# Altium schematic sheet Inresol_grid_inverter_DSPIC.SchDoc(Inresol_grid_inverter_DSPIC) (continued)

## Library data of the parts
C500 C502-C505 C510-C513 C515 C518 C521-C523 C525 C528 C541 C543 C550 C551 = C-100nF-50V-Cer-0603: Cap 0603 SM X7R 50V 10%; MFR: AVX; MFR PN: 06035C104K4T2A; Future PN (CT): 06035C104K4TN-CT; Future PN (TR): 06035C104K4TN; Rating: 50V 10%; Component Kind: Standard; ComponentLink1Description: Datasheet; ComponentLink1URL: http://www.avx.com/docs/Catalogs/cx7r.pdf; Library Name: EMEA CAPACITORS_FEB2016.SchLib; Verified: AD030615
C501 = C-10nF-25V-Cer-0603: Cap 0603 SM X7R 25V 10%; MFR: AVX; MFR PN: 06033C103KAT2A; Future PN (CT): 06033C103KATN-CT; Future PN (TR): 06033C103KATN; Rating: 25V 10%; Component Kind: Standard; ComponentLink1Description: Datasheet; ComponentLink1URL: http://www.avx.com/docs/Catalogs/ccog.pdf; Library Name: Future Capacitors.SCHLIB
C506 C507 C514 C516 C517 C524 C526 C537-C540 C542 C544-C549 C552-C567 = C-1nF-50V-Cer-0603: Cap 0603 SM X7R 50V 10%; MFR: VISHAY; MFR PN: VJ0603Y102KXACW1BC; Future PN (CT): 06035C102KATN-CT; Future PN (TR): 06035C102KATN; Rating: 50V 10%; Component Kind: Standard; ComponentLink1Description: Datasheet; ComponentLink1URL: http://www.vishay.com/docs/28548/vjw1bcbascomseries.pdf; Library Name: Future Capacitors.SCHLIB; Verified: AD030615
C508 = C-10uF-10V-Tant-1206: Cap 1206 SM Tant 10V 10%; MFR: AVX; MFR PN: TPSA106K010R0900; Future PN (CT): 106K10AP0900; Rating: 10V 10%; Component Kind: Standard; ComponentLink1Description: Datasheet; ComponentLink1URL: http://www.futureelectronics.com/en/technologies/passives/capacitors/tantalum-capacitors/Pages/5440110-TPSA106K010R0900.aspx?IM=0; Future PN (TR): 106K10AP0900; Library Name: Inresol_grid_inverter.SchLib
C509 C532 C533 = C-10uF-50V-Cer-1206: Cap 1206 SM X5R 50V 20%; MFR: TDK; MFR PN: C3216X5R1H106M160AB; Future PN (CT): TBD; Future PN (TR): 12065D106MATN; Rating: 50V 20%; Component Kind: Standard; ComponentLink1Description: Datasheet; ComponentLink1URL: http://product.tdk.com/capacitor/mlcc/en/documents/mlcc_commercial_general_en.pdf; Library Name: EMEA CAPACITORS_FEB2016.SchLib; Verified: AD030615
C519 C520 = C-10uF-10V-Cer-1206: Cap 1206 SM X7R 10V 10%; MFR: AVX; MFR PN: 1206ZG106ZAT2A; Future PN (CT): 1206ZG106ZATN-CT; Future PN (TR): 1206ZG106ZATN; Rating: 10V 10%; Component Kind: Standard; ComponentLink1Description: Datasheet; ComponentLink1URL: http://www.avx.com/docs/Catalogs/cy5v.pdf; Library Name: EMEA CAPACITORS_FEB2016.SchLib
C527 = C-22uF-16V-Tant-1210: Cap 1210 SM Tant 16V 10%; MFR: AVX; MFR PN: TPSB226K016R0600; Future PN (CT): 226K16BP0600-CT; Future PN (TR): 226K16BP0600; Rating: 16V 10%; Component Kind: Standard; ComponentLink1Description: Datasheet; ComponentLink1URL: http://www.avx.com/docs/masterpubs/tantniob.pdf; Library Name: Future Capacitors.SCHLIB; Verified: AD030615
C529 C534 = C-1uF-25V-Cer-0603: Cap 0603 SM X5R 25V 10%; MFR: YAGEO; MFR PN: CC0603KRX5R8BB105; Future PN (CT): 06033D105KATN-CT; Future PN (TR): 06033D105KATN; Rating: 25V 10%; Component Kind: Standard; ComponentLink1Description: Datasheet; ComponentLink1URL: http://www.yageo.com/documents/recent/UPY-GPHC_X5R_4V-to-50V_12.pdf; Library Name: Future Capacitors.SCHLIB; Verified: AD030615
C530 = C-47nF-50V-Cer-0603: Cap 0603 SM X7R 50V 10%; MFR: YAGEO; MFR PN: CC0603KRX7R9BB473; Future PN (CT): 06035C473KATN-CT; Future PN (TR): 06035C473KATN; Rating: 50V 10%; Component Kind: Standard; ComponentLink1Description: Datasheet; ComponentLink1URL: http://www.yageo.com/documents/recent/UPY-GPHC_X7R_6.3V-to-50V-11.pdf; Library Name: Future Capacitors.SCHLIB; Verified: AD030615
C531 = C-470PF-500VAC-Cer-8X5-LS10-Y1-EMEA-06-09-2017: Cap  8X5 LS10 RADIAL Y5U 500VAC 20% Y1; MFR: VISHAY; MFR PN: VY1471M31Y5UQ63V0; Apr'd: RAISED EMEA 12TH FEB 2013; Future PN (CT): TBD; Value: 470PF; Component Kind: Standard; ComponentLink1Description: Datasheet; ComponentLink1URL: http://www.vishay.com/docs/28537/emirfiy1.pdf; Future PN (TR): TBD; Library Name: Inresol_grid_inverter.SchLib
C535 = C-330uF-25V-Elec-8x10-EMEA-06-09-2017: Cap 8x10 SM Alum 25V 20%; MFR: Panasonic; MFR PN: EEE-FK1E331P; Future PN (CT): TBD; Rating: 25V 20%; Component Kind: Standard; ComponentLink1Description: Datasheet; ComponentLink1URL: https://industrial.panasonic.com/ww/products/capacitors/aluminum-capacitors/aluminum-cap-smd/fk-v/EEEFK1E331P; Future PN (TR): TBD; Library Name: Inresol_grid_inverter.SchLib
C536 = C-470PF-500VAC-Cer-8X5-LS10-Y1-EMEA-06-09-2017: Cap  8X5 LS10 RADIAL Y5U 500VAC 20% Y1; MFR: VISHAY; MFR PN: VY1471M31Y5UQ63V0; Apr'd: RAISED EMEA 12TH FEB 2013; Future PN (CT): TBD; Component Kind: Standard; ComponentLink1Description: Datasheet; ComponentLink1URL: http://www.vishay.com/docs/28537/emirfiy1.pdf; Future PN (TR): TBD; Library Name: Inresol_grid_inverter.SchLib
D500-D503 = D-SOD323-BAT760-7: Diode Schottky 30V 1A SMD; MFR: DIO; Future PN (CT): TBD; Component Kind: Standard; ComponentLink1Description: Datasheet; ComponentLink1URL: https://www.diodes.com/assets/Datasheets/ds30498.pdf; Future PN (TR): TBD; Library Name: Inresol_grid_inverter.SchLib
D504 = D-TSOP5-VREF-TLV431A-EMEA: Voltage reference adjustable 1.24V TLV431A; MFR: ON SEMI; Apr'd: raised EMEA 4th Jan 2013; Future PN (CT): TBA; Future PN (TR): TLV431ACDBVR; Component Kind: Standard; ComponentLink1Description: Datasheet; ComponentLink1URL: http://www.onsemi.com/pub_link/Collateral/TLV431A-D.PDF; Library Name: EMEA SEMICONDUCTORS_FEB2016.SchLib
J500 J501 J506 = J-HDR100-2x3-TH: Header, Male 6-Pin, LS100mil, Dual row; MFR: Tyco; Future PN (CT): NSH-06DB-S1-TG30-PB; Future PN (TR): TBD; Rating: 3 Amp; Value: 6 Pin; Component Kind: Standard; ComponentLink1Description: Datasheet; ComponentLink1URL: http://www.te.com/commerce/DocumentDelivery/DDEController?Action=showdoc&DocId=Customer+Drawing%7F103783%7FG2%7Fpdf%7FEnglish%7FENG_CD_103783_G2.pdf%7F103783-3; Library Name: Future Connectors.SCHLIB; Revision: 17-Jul-2002: Re-released for DXP Platform.; Signal Integrity: Connector
J502 = J-HDR100-2x7-TH: Header, Male 14-Pin,  LS100mil, Dual row; MFR: ADAM TECH; Future PN (CT): NSH-14DB-S2-T; Future PN (TR): TBD; Value: 2X7 Pin; Component Kind: Standard; ComponentLink1Description: Datasheet; ComponentLink1URL: http://www.adam-tech.com/rick/FinalSingle/CatPg250-254.pdf; Library Name: Future Connectors.SCHLIB; Signal Integrity: Connector
J503 J504 = J-RJ45-106066-2-EMEA-30-11-2016: Connector RJ45 RA TH Shielded 8 position; MFR: TE; Future PN (CT): TBD; Component Kind: Standard; ComponentLink1Description: Datasheet; ComponentLink1URL: http://www.te.com/usa-en/product-106066-2.html#pdp-docs-features; Future PN (TR): TBD; MFR PN: 106066-2; Signal Integrity: Connector; Library Name: Inresol_grid_inverter.SchLib
J505 = J-ADC-002A-1-2mm-EMEA-24-08-2017: Coax Connector 2mm; MFR: Adam Tech; Future PN (CT): TBD; Rating: 12V 1Amp; Rating: 3A; Component Kind: Standard; ComponentLink1Description: Datasheet; ComponentLink1URL: http://www.adam-tech.com/rick/FinalSingle/CatPg200-203.pdf; Future PN (TR): TBD; Value: 2mm; Library Name: Inresol_grid_inverter.SchLib
L500 = L-BLM21P-0805-330Ohm-1.5A-EMEA-06-09-2017: Ferrite Bead, 330ohm@100MHz, 0.09Ohm, 1.5A, 0805; MFR: MURATA; MFR PN: BLM21PG331SN1D; Future PN (CT): BLM21P331SGPT-CT; Future PN (TR): BLM21P331SGPT; Component Kind: Standard; ComponentLink1Description: Datasheet; ComponentLink1URL: http://www.murata.com/products/catalog/pdf/c31e.pdf; Library Name: Inresol_grid_inverter.SchLib
LED500-LED502 = LED-0603-Ever: Standard LED (Green); MFR: Everlight; MFR PN: QTLP600C4TR; Future PN (TR): QTLP600C-4.TR; Rating: 30mA; Value: GREEN LED; Component Kind: Standard; ComponentLink1Description: Datasheet; ComponentLink1URL: http://www.everlight.com/everlightinternational/lamp_datasheet/ds300084.pdf; Future PN (CT): N/A; Library Name: Future LED.SchLib
P500 = J-HDR100-6PIN-TH_TE: Header, Male 6-Pin, LS100mil; MFR: TE; Future PN (CT): TBD; Rating: 3Amp; Value: 6 Pin; Component Kind: Standard; ComponentLink1Description: Datasheet; ComponentLink1URL: http://www.te.com/catalog/pn/en/5-146278-6?RQPN=5-146278-6; Future PN (TR): TBD; Library Name: Future Connectors.SCHLIB; Signal Integrity: Connector
Q500 = Q-SOT23-BC847BLT1G: Transistor NPN SW, 45V 100mA SOT23; MFR: ON SEMI; Apr'd: YES; Future PN (CT): BC847BLT1-CT; Component Kind: Standard; ComponentLink1Description: Datasheet; ComponentLink1URL: http://www.onsemi.com/pub_link/Collateral/BC846ALT1-D.PDF; Future PN (TR): BC847BLT1; Library Name: Future Semiconductors.SCHLIB; Rating: 45V 100mA; Signal Integrity: MMBT2222A; Simulation: QMMBT2222A
Q501-Q504 = Q-PMV45EN2R: Mosfet N-Chan SOT23 30Vds 5.4A 42mOhmRds-EMEA-10-10-2016; MFR: NXP; Future PN (CT): TBD; Component Kind: Standard; ComponentLink1Description: Datasheet; ComponentLink1URL: http://images.ihscontent.net/vipimages/VipMasterIC/IC/PHGL/PHGLS23686/PHGLS23686-1.pdf; Future PN (TR): TBD; Rating: 30Vds 5.4A; Library Name: Inresol_grid_inverter.SchLib
R500 R503 R506 R510-R512 R529 R533-R538 = R-10k-100mW-0603: Res 0603 SM 100mW 1% 100ppm; MFR: YAGEO; MFR PN: RC0603FR-0710KL; Future PN (CT): CR0603-1002FTR-CT; Future PN (TR): CR0603-1002FTR; Component Kind: Standard; ComponentLink1Description: Datasheet; ComponentLink1URL: http://www.yageo.com/documents/recent/PYu-RC0603_51_RoHS_L_4.pdf; Library Name: Future Resistors.SCHLIB; Rating: 100mW 1%
R501 R504 = R-20k-100mW-0603: Res 0603 SM 100mW 1% 100ppm; MFR: YAGEO; MFR PN: RC0603FR-0720KL; Future PN (CT): CR0603-2002FTR-CT; Future PN (TR): CR0603-2002FTR; Component Kind: Standard; ComponentLink1Description: Datasheet; ComponentLink1URL: http://www.yageo.com/documents/recent/PYu-RC0603_51_RoHS_L_4.pdf; Library Name: Future Resistors.SCHLIB; Rating: 100mW 1%
R502 R505 R519 R526 R541-R546 R552-R557 R563-R584 = R-1k-100mW-0603: Res 0603 SM 100mW 1% 100ppm; MFR: YAGEO; MFR PN: RC0603FR-071KL; Future PN (CT): CR0603-1001FTR-CT; Future PN (TR): CR0603-1001FTR; Component Kind: Standard; ComponentLink1Description: Datasheet; ComponentLink1URL: http://www.yageo.com/documents/recent/PYu-RC0603_51_RoHS_L_4.pdf; Rating: 100mW 1%
  R502 R505: Library Name: Future Resistors.SCHLIB
  R519 R526 R541-R546 R552-R557 R563-R584: Library Name: EMEA RESISTORS_FEB2016.SchLib
R507-R509 = R-5.6k-100mW-0603: Res 0603 SM 100mW 1% 100ppm; MFR: YAGEO; MFR PN: RC0603FR-075K6L; Future PN (CT): CR0603-5601FTR-CT; Future PN (TR): CR0603-5601FTR; Component Kind: Standard; ComponentLink1Description: Datasheet; ComponentLink1URL: http://www.yageo.com/documents/recent/PYu-RC0603_51_RoHS_L_4.pdf; Library Name: Future Resistors.SCHLIB; Rating: 100mW 1%
R513-R515 R523 R524 R539 R540 = R-51k-100mW-0603: Res 0603 SM 100mW 1% 100ppm; MFR: YAGEO; MFR PN: RC0603FR-0751KL; Future PN (CT): CR0603-5102FTR-CT; Future PN (TR): CR0603-5102FTR; Component Kind: Standard; ComponentLink1Description: Datasheet; ComponentLink1URL: http://www.yageo.com/documents/recent/PYu-RC0603_51_RoHS_L_4.pdf; Library Name: Future Resistors.SCHLIB; Rating: 100mW 1%
R516-R518 = R-220R-100mW-0603: Res 0603 SM 100mW 1% 100ppm; MFR: YAGEO; MFR PN: RC0603FR-07220RL; Future PN (CT): CR0603-2200FTR-CT; Future PN (TR): CR0603-2200FTR; Component Kind: Standard; ComponentLink1Description: Datasheet; ComponentLink1URL: http://www.yageo.com/documents/recent/PYu-RC0603_51_RoHS_L_4.pdf; Library Name: Future Resistors.SCHLIB; Rating: 100mW 1%
R520 R525 = R-6.8k-100mW-0603: Res 0603 SM 100mW 1% 100ppm; MFR: YAGEO; MFR PN: RC0603FR-076K8L; Future PN (CT): CR0603-6801FTR-CT; Future PN (TR): CR0603-6801FTR; Component Kind: Standard; ComponentLink1Description: Datasheet; ComponentLink1URL: http://www.yageo.com/pdf/Pu-RC0603_51_PbFree_L_2.pdf; Library Name: Future Resistors.SCHLIB; Rating: 100mW 1%
R521 = R-680R-100mW-0603: Res 0603 SM 100mW 1% 100ppm; MFR: YAGEO; MFR PN: RC0603FR-07680RL; Future PN (CT): CR0603-6800FTR-CT; Future PN (TR): CR0603-6800FTR; Component Kind: Standard; ComponentLink1Description: Datasheet; ComponentLink1URL: http://www.yageo.com/documents/recent/PYu-RC0603_51_RoHS_L_4.pdf; Library Name: Future Resistors.SCHLIB; Rating: 100mW 1%
R522 = R-120R-1W-2512-EMEA-01-12-2016: Res 2512 SM 1W 1%; MFR: VISHAY; MFR PN: CRCW2512120RJNEG; Future PN (CT): TBD; Component Kind: Standard; ComponentLink1Description: Datasheet; ComponentLink1URL: http://www.vishay.com/docs/20035/dcrcwe3.pdf; Future PN (TR): TBD; Library Name: Inresol_grid_inverter.SchLib
R527 R528 = R-330R-100mW-0603: Res 0603 SM 100mW 1% 100ppm; MFR: YAGEO; MFR PN: RC0603FR-07330RL; Future PN (CT): CR0603-3300FTR-CT; Future PN (TR): CR0603-3300FTR; Component Kind: Standard; ComponentLink1Description: Datasheet; ComponentLink1URL: http://www.yageo.com/documents/recent/PYu-RC0603_51_RoHS_L_4.pdf; Library Name: Future Resistors.SCHLIB; Rating: 100mW 1%
R530 R547-R550 = R-100k-100mW-0603: Res 0603 SM 100mW 1% 100ppm; MFR: ROHM; MFR PN: MCR03EZPFX1003; Future PN (CT): CR0603-1003FTR-CT; Future PN (TR): CR0603-1003FTR; Component Kind: Standard; ComponentLink1Description: Datasheet; ComponentLink1URL: http://www.rohm.com/products/databook/r/pdf/mcr03.pdf; Library Name: Future Resistors.SCHLIB; Rating: 100mW 1%
R531 = R-150R-125mW-0805: Res 0805 SM 125mW 1% 100ppm; MFR: VISHAY; MFR PN: CRCW0805150RFKEA; Future PN (CT): CR0805-1500FTR-CT; Future PN (TR): CR0805-1500FTR; Component Kind: Standard; ComponentLink1Description: Datasheet; ComponentLink1URL: http://www.vishay.com/docs/20035/dcrcwe3.pdf; Library Name: EMEA RESISTORS_FEB2016.SchLib; Signal Integrity: Res; Simulation: RESISTOR
R532 = R-3.3k-100mW-0603: Res 0603 SM 100mW 1% 100ppm; MFR: YAGEO; MFR PN: RC0603FR-073K3L; Future PN (CT): CR0603-3301FTR-CT; Future PN (TR): CR0603-3301FTR; Component Kind: Standard; ComponentLink1Description: Datasheet; ComponentLink1URL: http://www.yageo.com/documents/recent/PYu-RC0603_51_RoHS_L_4.pdf; Library Name: Future Resistors.SCHLIB; Rating: 100mW 1%
R551 = R-100R-100mW-0603: Res 0603 SM 100mW 1% 100ppm; MFR: YAGEO; MFR PN: RC0603FR-07100RL; Future PN (CT): CR0603-1000FTR-CT; Future PN (TR): CR0603-1000FTR; Component Kind: Standard; ComponentLink1Description: Datasheet; ComponentLink1URL: http://www.yageo.com/documents/recent/PYu-RC0603_51_RoHS_L_4.pdf; Library Name: Future Resistors.SCHLIB; Rating: 100mW 1%
R558-R562 = R-0R-100mW-0603: Res 0603 SM 100mW; MFR: YAGEO; MFR PN: RC0603JR-070RL; Future PN (CT): CR0603-0000JTR-R-CT; Future PN (TR): CR0603-0000JTR-R; Component Kind: Standard; ComponentLink1Description: Datasheet; ComponentLink1URL: http://www.rohm.com/products/databook/r/pdf/mcr03.pdf; Library Name: Future Resistors.SCHLIB; Rating: 100mW
R585 = R-0R-250mW-1206: Res 1206 SM 250mW; MFR: YAGEO; MFR PN: RC1206JR-070RL; Future PN (CT): CR1206-0000JTR-CT; Future PN (TR): CR1206-0000JTR; Component Kind: Standard; ComponentLink1Description: Datasheet; ComponentLink1URL: http://www.yageo.com/documents/recent/PYu-RC1206_51_RoHS_L_4.pdf; Library Name: Future Resistors.SCHLIB; Rating: 250mW
SW500 = SW-SPST-MOM-2-1437565-7-emea-18-5-15: Low Profile MOM Tac SPST SMD GW-leads; MFR: TE; Future PN (TR): FSM2JSMA; Rating: 32VDC 50mA; Component Kind: Standard; ComponentLink1Description: Datasheet; ComponentLink1URL: http://www.te.com/commerce/DocumentDelivery/DDEController?Action=selcritrslt&searchby=part&searchfor=2-1437565-7&doctypes=All&docformats=All&doclangs=All&TCPN=2-1437565-7; Library Name: EMEA MISC_FEB2016.SchLib; MFR PN: 2-1437565-7
TP500-TP504 TP507-TP511 TP513 TP514 TP516 TP518 TP520 TP522 TP523 = TP-TEST POINT-LOOP WT-EMEA-15-10-15: Test Point; MFR: NA; Future PN (CT): NONE; Future PN (TR): TBD; ComponentLink1Description: Datasheet; Library Name: EMEA MISC_FEB2016.SchLib; MFR PN: NA; Signal Integrity: Connector
U500 = U-TQFP64-dsPIC33EP128GS706: IC, dsPIC, 16-Bit Digital Signal Controller with High-Speed PWM, ADC and Comparators; MFR: Microchip; Apr'd: NO; Future PN (CT): TBD; Component Kind: Standard; ComponentLink1Description: Datasheet; ComponentLink1URL: http://www.microchip.com/wwwproducts/en/dsPIC33EP128GS706; Future PN (TR): TBD; Library Name: Inresol_grid_inverter.SchLib
U501 = U-APX823-26W5G-7: Interface MCU Supervisor Monitor Reset Open-Drain SOT23-5 SM 2.63V; MFR: DIODES; Apr'd: YES; Future PN (CT): TBD; Rating: 2.63V Monitor; Component Kind: Standard; ComponentLink1Description: Datasheet; ComponentLink1URL: http://diodes.com/datasheets/APX823_824_825A.pdf; Future PN (TR): TBD; Library Name: Future Semiconductors.SCHLIB; Verified: AD031615
U502 = U-NCP1117ST50T3G-EMEA-24-08-2017: PMIC LDO Regulator SOT223 SM UpTo 18Vin 1A 5Vout; MFR: ON SEMI; Future PN (CT): TBD; Rating: 5V reg; VModel: xxMMDDYY; Component Kind: Standard; ComponentLink1Description: Datasheet; ComponentLink1URL: http://images.ihscontent.net/vipimages/VipMasterIC/IC/ONSM/ONSM-S-A0000202102/ONSM-S-A0000202102-1.pdf; Future PN (TR): TBD; Library Name: Inresol_grid_inverter.SchLib; Verified: AD032816
U503 = U-SO8-VO2601-X007T-EMEA-15-03-2017: IC, Single Chan 10MBPS Logic Gate Opto Coupler SO8; MFR: Vishay; Future PN (CT): TBD; Rating: 3.3V 10MPS; Component Kind: Standard; ComponentLink1Description: Datasheet; ComponentLink1URL: http://www.vishay.com/docs/84732/6n137.pdf; Future PN (TR): TBD; MFR PN: VO2601-X007T; Library Name: Inresol_grid_inverter.SchLib
U504 = U-SO14-SP3083-EMEA-07-09-2017: Low-Power, Slew-Rate-Limited RS-485/RS-422 Transceiver; MFR: EXAR; Future PN (CT): TBD; Component Kind: Standard; ComponentLink1Description: Datasheet; ComponentLink1URL: https://www.exar.com/content/document.ashx?id=1255&languageid=1033&type=Datasheet&partnumber=SP3083E&filename=SP3080E-SP3088E_101_082611.pdf&part=SP3083E; Future PN (TR): TBD; Library Name: Inresol_grid_inverter.SchLib
U505 = U-SO8-VO2630-X007T-EMEA-15-03-2017: IC, High Speed Double TTL Optocoupler SO8; MFR: Vishay; Apr'd: YES; Future PN (CT): TBD; Rating: 3.3-7V; Component Kind: Standard; ComponentLink1Description: Datasheet; ComponentLink1URL: http://www.vishay.com/docs/84732/6n137.pdf; Future PN (TR): TBD; MFR PN: VO2630-X007T; Library Name: Inresol_grid_inverter.SchLib
U506 = REC15-2415SZ/H3/M-EMEA-12-12-2016: Isolated DC-DC Vin: 9-36V, Vout:15V, 15W, 3kVDC; MFR: Recom; Changes: 1x1 inch 1.6kV module replaced with 2x1 inch 3kV; Future PN (CT): TBD; Changes-date: 20161202; Component Kind: Standard; ComponentLink1Description: Datasheet; ComponentLink1URL: http://www.recom-power.com/pdf/Econoline/REC15-S_D_Z_M.pdf; Distributor: Suggested by Future Electronics; Future PN (TR): TBD; Rating: 15W 3kVDC; Size: 50.8mm x 25.4 mm THT; Value: Vin: 9-36V; Vout:15V;; Library Name: Inresol_grid_inverter.SchLib
U507 U508 = U-SOIC8-LM7322MA-EMEA_20122017: IC, Gen Purpose Dual OpAmp LM7322MA; MFR: TI; Future PN (TR): NA; Rating: 5V; Component Kind: Standard; ComponentLink1Description: Datasheet; ComponentLink1URL: http://uk.farnell.com/fairchild-semiconductor/lmv358am8x/ic-op-amp-1-4mhz-1-5v-us-soic-8/dp/1262552; Library Name: Inresol_grid_inverter.SchLib
